# Altium schematic sheet StarDice_Alternative_Controller_Shutters.SchDoc
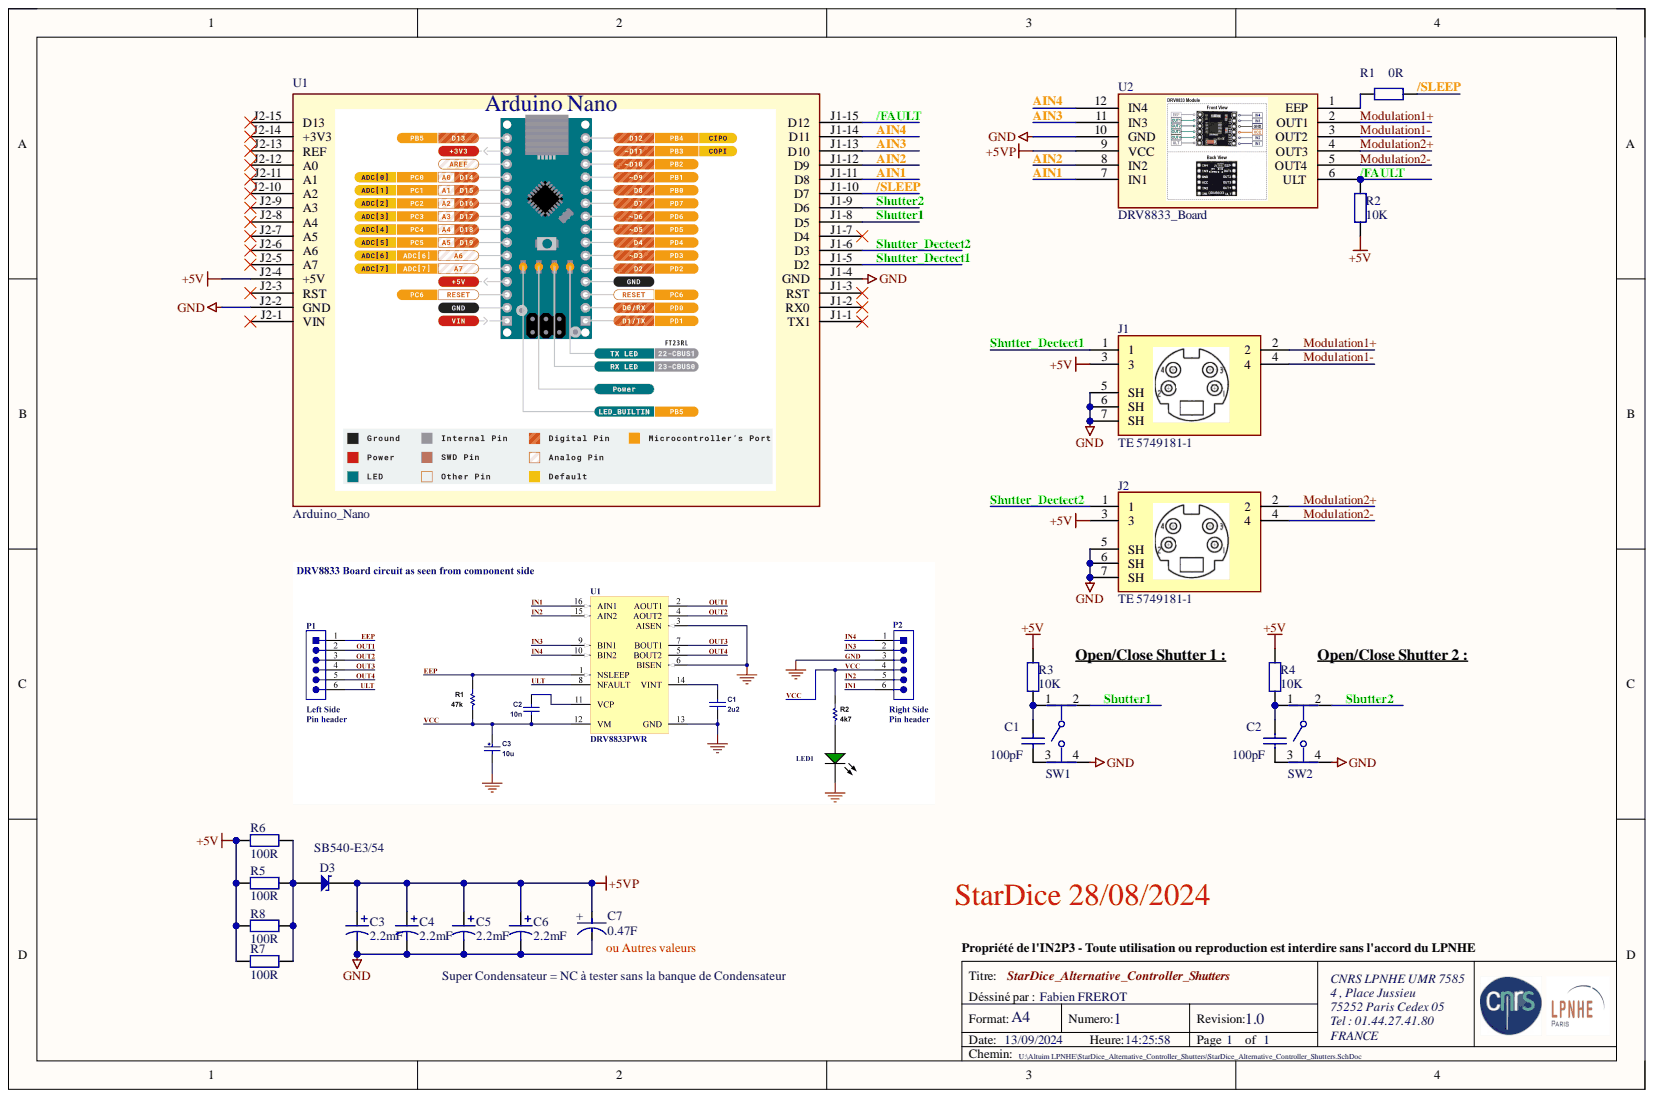
[diagram p0: StarDice_Alternative_Controller_Shutters.SchDoc]

## Parts
C1  value 100pF  [C603]  (hidden comment: Capacitor CMS)
C2  value 100pF  [C603]  (hidden comment: Capacitor CMS)
C3  value 2.2mF  [CAPPA10x20]  (hidden comment: Polarized Capacitor Aluminuim)
C4  value 2.2mF  [CAPPA10x20]  (hidden comment: Polarized Capacitor Aluminuim)
C5  value 2.2mF  [CAPPA10x20]  (hidden comment: Polarized Capacitor Aluminuim)
C6  value 2.2mF  [CAPPA10x20]  (hidden comment: Polarized Capacitor Aluminuim)
C7  value 0.47F  [FP-SCMQ14-MFG]  (hidden comment: KYOCERA AVX SCMR14C474PRBA0)
D3  SB540-E3/54  [DO-201AD]
J1  TE 5749181-1  [TE-5749181-1]
J2  TE 5749181-1  [TE-5749181-1]
R1  value 0R  [R805]  (hidden comment: Res)
R2  value 10K  [R805]  (hidden comment: Res)
R3  value 10K  [R603]  (hidden comment: Res)
R4  value 10K  [R603]  (hidden comment: Res)
R5  value 100R  [Resistor 2W Vertical Mount]  (hidden comment: RES_2W_Vertical)
R6  value 100R  [Resistor 2W Vertical Mount]  (hidden comment: RES_2W_Vertical)
R7  value 100R  [Resistor 2W Vertical Mount]  (hidden comment: RES_2W_Vertical)
R8  value 100R  [Resistor 2W Vertical Mount]  (hidden comment: RES_2W_Vertical)
SW1  ALCOSWITCH - TE CONNECTIVITY 2-1825910-7 (Gamme FSMRAJ)  [ALCOSWITCH - TE CONNECTIVITYTE 2-1825910-7 (Gamme FSMRAJ)]  (value not drawn)
SW2  ALCOSWITCH - TE CONNECTIVITY 2-1825910-7 (Gamme FSMRAJ)  [ALCOSWITCH - TE CONNECTIVITYTE 2-1825910-7 (Gamme FSMRAJ)]  (value not drawn)
U1  Arduino_Nano  [Arduino_Nano]
U2  DRV8833_Board  [DRV8833 Motor Driver Module]

## Nets
+5V: J1.3 J2.3 R2.1 R3.2 R4.2 R5.2 R6.2 R7.2 R8.2 U1.J2-4(+5V)
+5VP: C3.1 C4.1 C5.1 C6.1 C7.1 D3.2 U2.9(VCC)
GND: C3.2 C4.2 C5.2 C6.2 C7.2 J1.5(SH) J1.6(SH) J1.7(SH) J2.5(SH) J2.6(SH) J2.7(SH) SW1.4 SW2.4 U1.J1-4(GND) U1.J2-2(GND) U2.10(GND)
/FAULT: R2.2 U1.J1-15(D12) U2.6(ULT)
/SLEEP: R1.1 U1.J1-10(D7)
AIN1: U1.J1-11(D8) U2.7(IN1)
AIN2: U1.J1-12(D9) U2.8(IN2)
AIN3: U1.J1-13(D10) U2.11(IN3)
AIN4: U1.J1-14(D11) U2.12(IN4)
Modulation1+: J1.2 U2.2(OUT1)
Modulation1-: J1.4 U2.3(OUT2)
Modulation2+: J2.2 U2.4(OUT3)
Modulation2-: J2.4 U2.5(OUT4)
Shutter1: SW1.2 U1.J1-8(D5)
Shutter2: SW2.2 U1.J1-9(D6)
Shutter_Dectect1: J1.1 U1.J1-5(D2)
Shutter_Dectect2: J2.1 U1.J1-6(D3)
NetC1_1: C1.1 SW1.3
NetC1_2: C1.2 R3.1 SW1.1
NetC2_1: C2.1 SW2.3
NetC2_2: C2.2 R4.1 SW2.1
NetD3_1: D3.1 R5.1 R6.1 R7.1 R8.1
NetR1_2: R1.2 U2.1(EEP)

Not connected: U1 J1-1(TX1) J1-2(RX0) J1-3(RST) J1-7(D4) J2-1(VIN) J2-3(RST) J2-5(A7) J2-6(A6) J2-7(A5) J2-8(A4) J2-9(A3) J2-10(A2) J2-11(A1) J2-12(A0) J2-13(REF) J2-14(+3V3) J2-15(D13)

## Library data of the parts
C1 C2 = Cap: Code Commande: F183-3871; Component Kind: Standard; Description: Capacitor CMS; Library Name: pSDLA.IntLib
C3-C6 = Cap  Aluminuim Pol: Nichicon RNL1C222MDS1 2200 µF 20% 16V TH Aluminum - Polymer Capacitors Radial, Can 8mOhm 2000 Hrs @ 105°C; Code Commande: Digikey 493-16394-ND; Component Kind: Standard; Library Name: LPNHE_Library.IntLib
C7 = SCMR14C474PRBA0: Supercondensateur, 0.47F, 5V c.c., Traversant SCMR14C474PRBA0; Code Commande: RS250-6970; Component Kind: Standard; Library Name: LPNHE_Library.IntLib
D3 = Diode_Schottky: SB540-E3/54 Diode traversante Vishay, 5A, 40V, DO-201AD; Code Commande: F955-0399; Component Kind: Standard; Value: SB540-E3/54; Library Name: LPNHE_Library.IntLib
J1 J2 = TE 5749181-1: TE 5749181-1 Mini DIN, Wire-to-Board, 4 Position, Fully Loaded, Keyed Mating Alignment Type, PCB Mount Retention, 6.5 mm [.26 in] Centerline, DIN Connectors; Code Commande: TME MDC-204 / F379-1398; Value: NINIGI MDC-204  /TE 5749181-1; Component Kind: Standard; Library Name: LPNHE_Library.IntLib
R1 = Res: Resistance CMS; Code Commande: F261-4475; Component Kind: Standard; Library Name: pSDLA.IntLib
R2 = Res: Resistance CMS; Code Commande: F161-2522; Component Kind: Standard; Library Name: pSDLA.IntLib
R3 R4 = Res: Resistance CMS; Code Commande: F233-5832; Component Kind: Standard; Library Name: pSDLA.IntLib
R5-R8 = RES_2W_Vertical: 2W Resistor Vertical Mount Résistance Céramique 100Ω ±10%, Céramique, 2W Axial; Code Commande: RS178-6553; Component Kind: Standard; Library Name: LPNHE_Library.IntLib
SW1 SW2 = ALCOSWITCH - TE CONNECTIVITY 2-1825910-7 (Gamme FSMRAJ): ALCOSWITCH - TE CONNECTIVITY 2-1825910-7 Commutateur tactile, FSMRAJ, A déclencheur supérieur, Traversant, Bouton rond, 160 gf; Code Commande: F253-3101; Component Kind: Standard; Value: ALCOSWITCH -TE CONNECTIVITY 2-1825910-7; Library Name: MicroDial_Stagiare.IntLib
U1 = Arduino_Nano: Arduino_Nano ATmega328; Code Commande: SKU A000005 Barcode 7630049200173 / RS696-1667; Component Kind: Standard; Value: Arduino_Nano; Library Name: LPNHE_Library.IntLib
U2 = DRV8833_Board: Code Commande: ElectroProto DRV8833; Component Kind: Standard; Description: DRV8833_Board; Value: DRV8833_Board; Library Name: LPNHE_Library.IntLib
